# Altium schematic sheet [4]-Battery.SchDoc
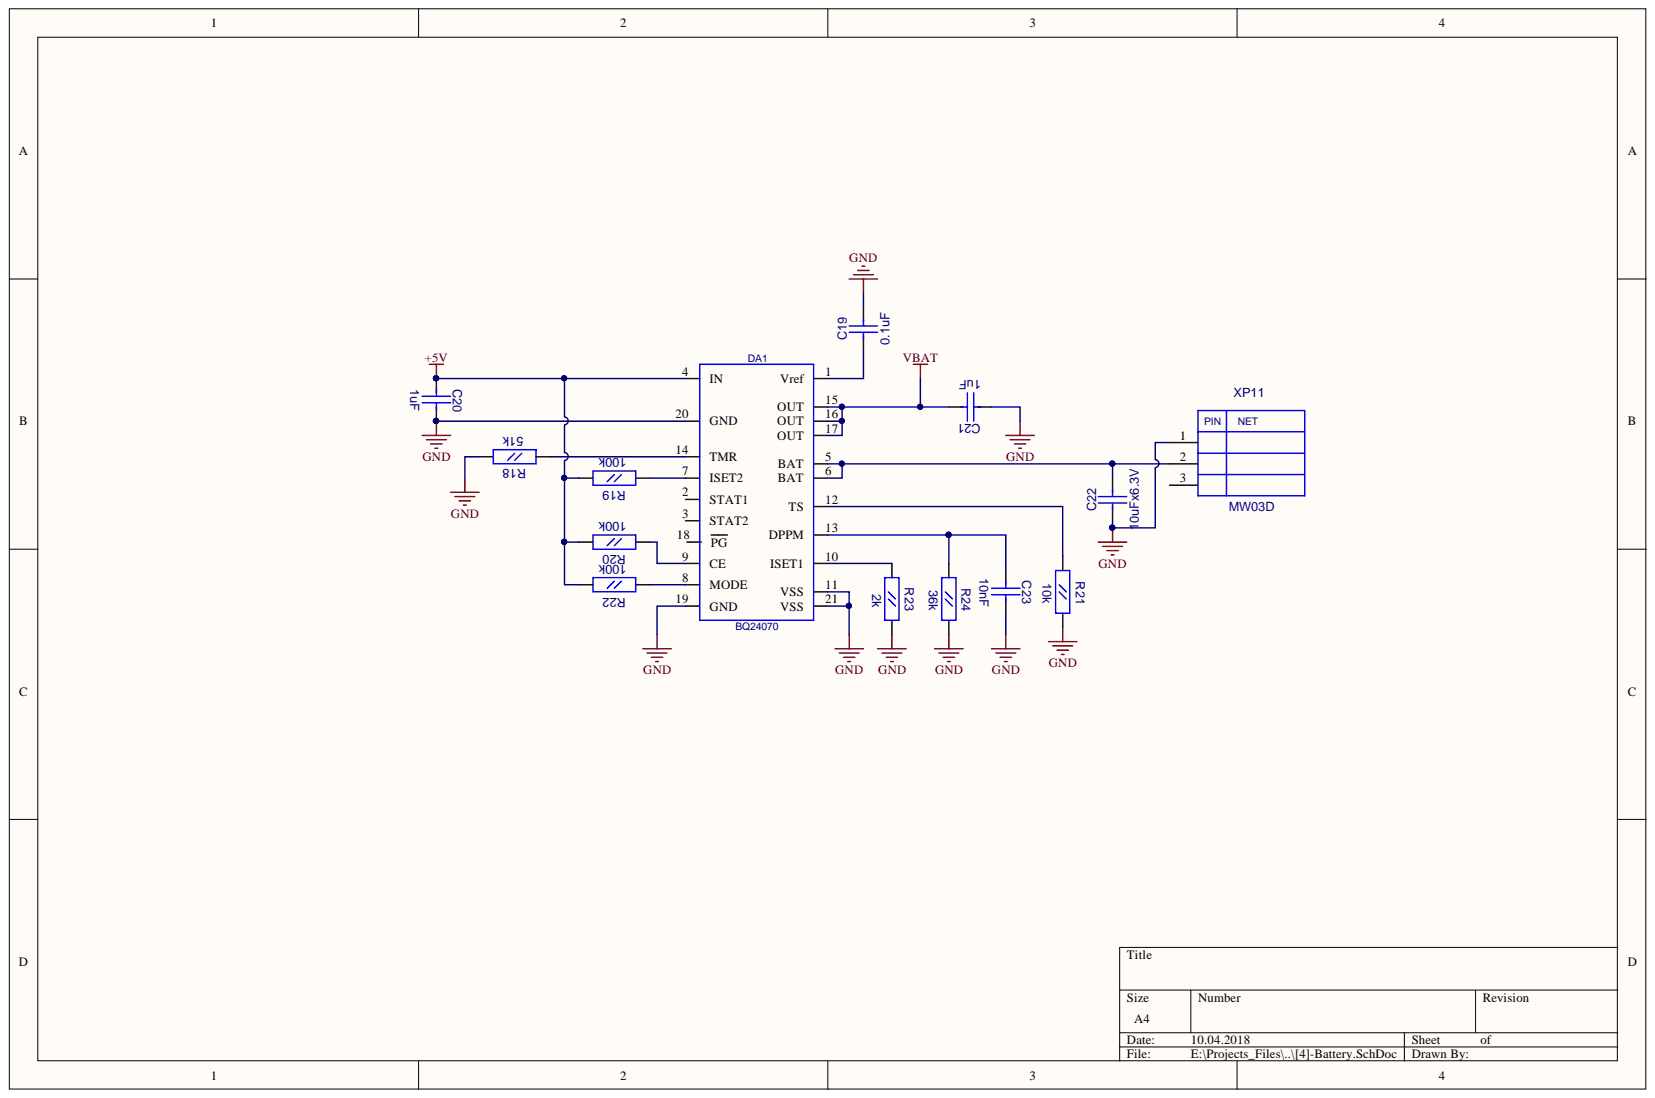
[diagram p0: [4]-Battery.SchDoc]

## Parts
C19  0.1uF  [CC0603]
C20  1uF  [CC0603]
C21  1uF  [CC0603]
C22  10uFx6.3V  [CC0805]
C23  10nF  [CC0603]
DA1  BQ24070  [P-PQFP-N20]
R18  51k  [RC0603]
R19  100k  [RC0603]
R20  100k  [RC0603]
R21  10k  [RC0603]
R22  100k  [RC0603]
R23  2k  [RC0603]
R24  36k  [RC0603]
XP11  MW03D  [MW03D]

## Nets
+5V: C20.1 DA1.4(IN) R19.2 R20.2 R22.2
GND: C19.2 C20.2 C21.1 C22.1 C23.2 DA1.11(VSS) DA1.19(GND) DA1.20(GND) DA1.21(VSS) R18.2 R21.2 R23.2 R24.2 XP11.1
VBAT: C21.2 DA1.15(OUT) DA1.16(OUT) DA1.17(OUT)
NetC19_1: C19.1 DA1.1(Vref)
NetC22_2: C22.2 DA1.5(BAT) DA1.6(BAT) XP11.2
NetC23_1: C23.1 DA1.13(DPPM) R24.1
NetDA1_7: DA1.7(ISET2) R19.1
NetDA1_8: DA1.8(MODE) R22.1
NetDA1_9: DA1.9(CE) R20.1
NetDA1_10: DA1.10(ISET1) R23.1
NetDA1_12: DA1.12(TS) R21.1
NetDA1_14: DA1.14(TMR) R18.1

Not connected: DA1 2(STAT1) 3(STAT2) 18(PG); XP11 3

## Library data of the parts
C19-C21 C23 = CC0603: Component Kind: Standard; Description: CC0603; Library Name: gprs_modem_2.SchLib
  C19: Value: 0.1uF
  C20 C21: Value: 1uF
  C23: Value: 10nF
C22 = CC0805: Component Kind: Standard; Description: CC0805; Value: 10uFx6.3V; Library Name: gprs_modem_2.SchLib
R18-R24 = RC0603: Component Kind: Standard; Description: RC0603; Library Name: gprs_modem_2.SchLib
  R18: Value: 51k
  R19 R20 R22: Value: 100k
  R21: Value: 10k
  R23: Value: 2k
  R24: Value: 36k
DA1 = BQ24070: Component Kind: Standard; Description: BQ24070; Library Name: gprs_modem.SchLib
XP11 = MW03D: Component Kind: Standard; Description: MW03D; Library Name: gprs_modem.SchLib
